# Altium schematic sheet Power.SchDoc
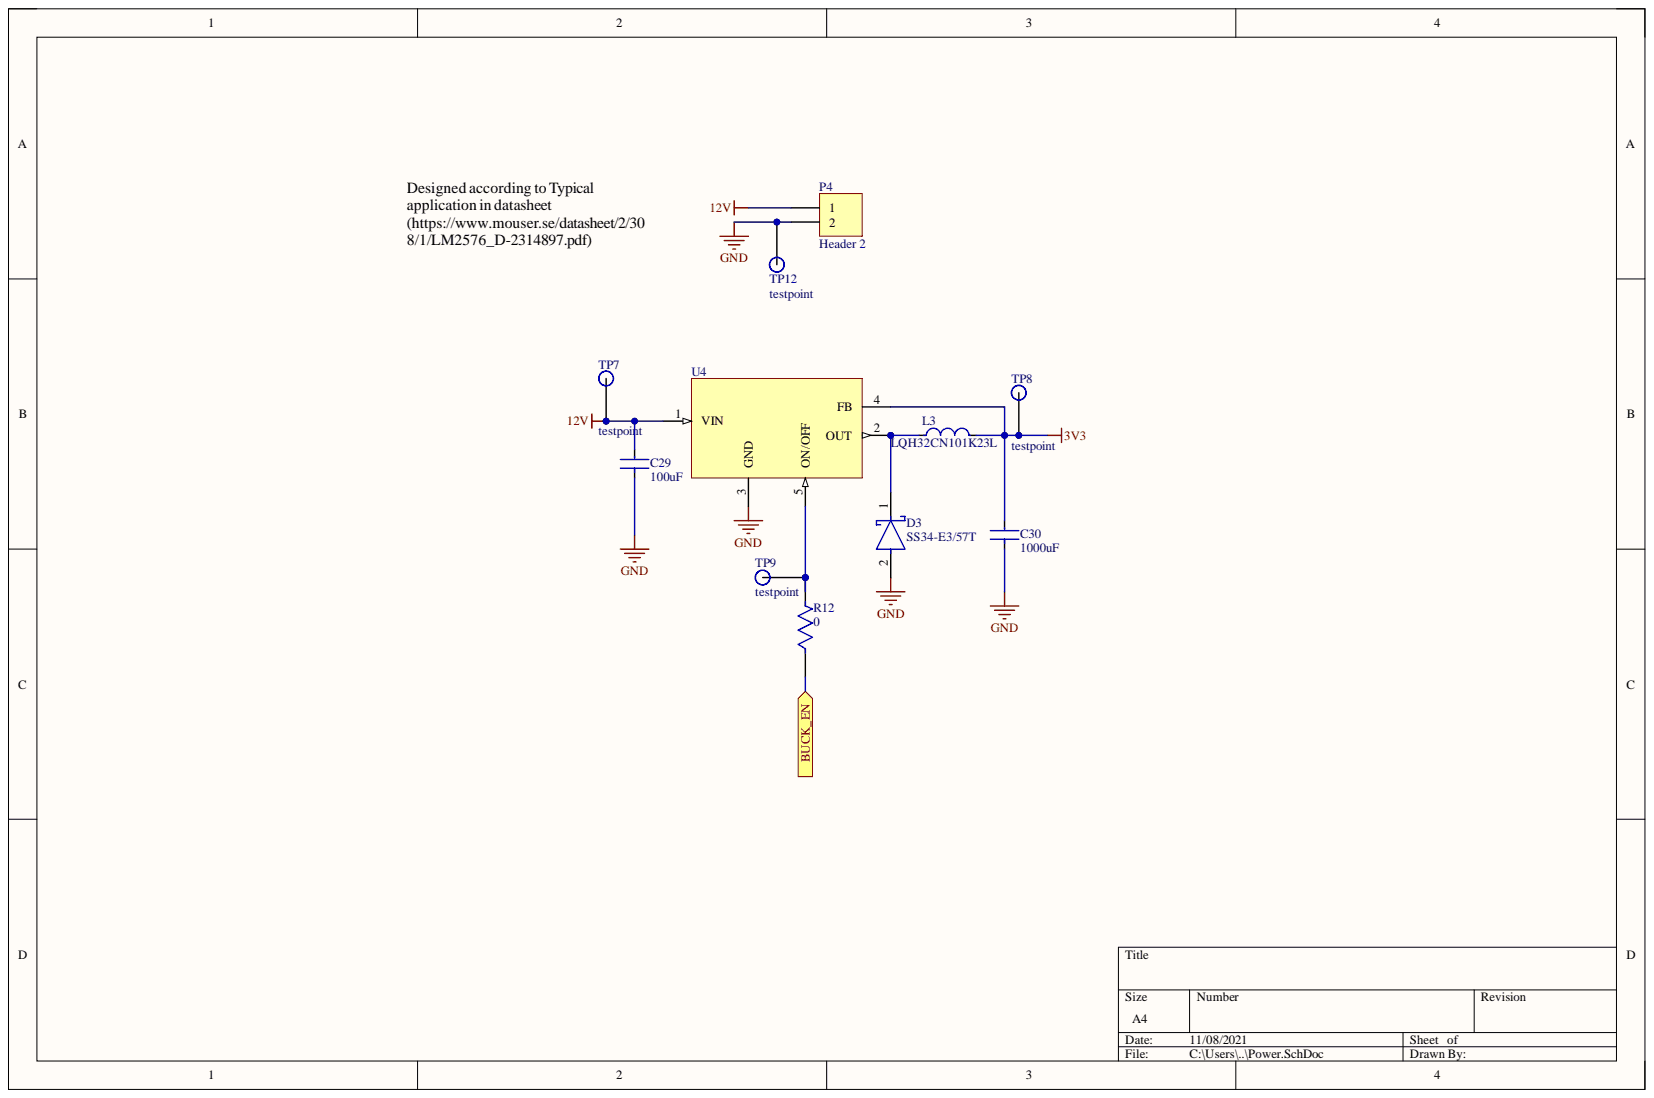
[diagram p0: Power.SchDoc]

## Parts
C29  100uF  [FP-GCJ21B-0_3-IPC_A]
C30  1000uF  [FP-GCJ21B-0_3-IPC_A]
D3  SS34-E3/57T  [FP-95402-MFG]
L3  LQH32CN101K23L  [FP-LQH32CH_23-MFG]
P4  Header 2  [HDR1X2]
R12  0  [FP-AC0603-MFG]
TP7  testpoint  [Testpoint]
TP8  testpoint  [Testpoint]
TP9  testpoint  [Testpoint]
TP12  testpoint  [Testpoint]
U4  LM2576D2TR4-3.3G  [D2PAK-5]  (value not drawn)

## Ports
BUCK_EN

## Nets
3V3: C30.2 L3.2 TP8.1 U4.4(FB)
12V: C29.2 P4.1 TP7.1 U4.1(VIN)
GND: C29.1 C30.1 D3.2 P4.2 TP12.1 U4.3(GND)
BUCK_EN: R12.1 ; port BUCK_EN
NetD3_1: D3.1 L3.1 U4.2(OUT)
NetR12_2: R12.2 TP9.1 U4.5(ON/OFF)

## Library data of the parts
C29 C30 = CMP-2007-03210-2: Multilayer Ceramic Capacitors MLCC - SMD/SMT 0805 2.2uF 10%, 16VDC,  X7R 15%; Voltage Rating: 16V; Capacitance: 2.2uF; Case Code (Imperial): 0805; Case Code (Metric): 2012; Case/Package: 0805; Component Kind: Standard; Depth: 1.25mm; Dielectric: X7R; Features: Soft Termination; Height: 1.25mm; Lead Free: Lead Free; Length: 2mm; Library Name: Altium Content Vault; Max Operating Temperature: 125°C; Min Operating Temperature: -55°C; Mount: Surface Mount; Package Quantity: 1; Packaging: Tape and Reel; Radiation Hardening: No; Ratings: AEC-Q200; Termination: SMD/SMT; Thickness: 0.057inch; Tolerance: 10%; Voltage Rating (DC): 16V; Width: 0.049inch
D3 = CMP-02539-000012-1: DIODE SCHOTTKY 40V 3A DO214AB; Manufacturer: Vishay; Max Junction Temp: +150°C; Max Surge Forward Current: 100A; Peak Reverse Current: 0.5mA; Standoff Height: 0mm; Automotive: No; Category: Diode; Component Kind: Standard; ComponentLink2Description: Datasheet; ComponentLink2URL: http://www.vishay.com/docs/88751/ss32.pdf; Device Class L1: Discrete Semiconductors; Device Class L2: Diodes; Device Class L3: Schottky Diodes; Digikey Description: DIODE SCHOTTKY 40V 3A DO214AB; Footprint Url: http://www.vishay.com/docs/95402/smc.pdf; Forward Current: 3A; Height: 2.62mm; Lead Free: Yes; Library Name: Altium Content Vault; Manufacturer Part Number: SS34-E3/57T; Max Repetitive Reverse Voltage: 40V; Mouser Description: Schottky Diodes & Rectifiers 3.0 Amp 40 Volt; Octopart: https://octopart.com/ss34-e3%2F57t-vishay-39552907; Package: 95402; RoHS: TRUE; Temperature: -55°C to +150°C; Temperature Range High: +150°C; Temperature Range Low: -55°C
L3 = CMP-06042-006648-2: Wire Wound Ferrite Inductor for Power Lines 100μH ±10% 4.55Ω 100mA 1210; Current: 1.1A; DC Resistance (DCR): 3.5R; Frequency: 10MHz; Inductance: 100uH; Q Factor: 40; Shielding: Unshielded; Test Frequency: 1MHz; Case Code (Imperial): 1210; Case Code (Metric): 3225; Case/Package: 1210; Component Kind: Standard; Core Material: Ferrite; Current Rating: 100mA; DC Current: 100mA; Depth: 2.7mm; Height: 2mm; Inductance Tolerance: 10%; Inductor Type: Wirewound; Lead Free: Lead Free; Length: 3.2mm; Library Name: Altium Content Vault; Max DC Current: 100mA; Max Operating Temperature: 85°C; Military Standard: Not; Min Operating Temperature: -40°C; Mount: Surface Mount; Number of Pins: 2; Package Quantity: 2000; Packaging: Tape and Reel; Radiation Hardening: No; Rated Current-Max: 100mA; REACH SVHC: No SVHC; RoHS Compliant: Yes; Self Resonant Frequency: 10MHz; Series: LQH32C_33; Termination: SMD/SMT; Tolerance: 10%; Weight: 0.002116oz; Width: 2.5mm
P4 = Header 2: Header, 2-Pin; Component Kind: Standard; LatestRevisionDate: 17-Jul-2002; LatestRevisionNote: Re-released for DXP Platform.; Library Name: Miscellaneous Connectors.IntLib; Signal Integrity: Connector; Publisher: Altium Limited
R12 = CMP-03412-025180-1: RES SMD 60.4 OHM 1% 1/10W 0603; Manufacturer: Yageo; Manufacturer Part Number: AC0603FR-0760R4L; Automotive Grade: Grade 0; Power Rating: 0.1W; Resistance: 60.4Ω; Tolerance: 0.01; Value: 60.4Ω; Voltage Rating: 75V; Automotive: Yes; Category: Res; Component Kind: Standard; ComponentLink2Description: Datasheet; ComponentLink2URL: http://www.yageo.com/NewPortal/yageodocoutput?fileName=/pdf/R-Chip/PYu-AC_51_RoHS_L_6.pdf; Composition: Thick Film; Device Class L1: Passive Components; Device Class L2: Resistors; Device Class L3: Chip SMD Resistors; Digikey Description: RES SMD 60.4 OHM 1% 1/10W 0603; Footprint Url: http://www.yageo.com/exep/pages/download/literatures/PYu-R_Mount_9.pdf; Height: 0.55mm; Ipc Land Pattern Name: RESC16080X45; Lead Free: Yes; Library Name: Altium Content Vault; Mouser Description: Res Thick Film 0603 60.4 Ohm 1% 0.1W(1/10W) ±100ppm/C Epoxy Pad SMD Automotive T/R; Octopart: https://octopart.com/ac0603fr-0760r4l-yageo-71367350; Package: AC0603; RoHS: TRUE; Series: AC; Temperature: -55°C to +155°C; Temperature Range High: +155°C; Temperature Range Low: -55°C
U4 = LM2576D2TR4-3.3G: http://octopart.com/lm2576d2tr4-5g-on+semiconductor-46514222; Availability: Unavailable; Component Kind: Standard; Library Name: Schlib.SchLib; MP: LM2576D2TR4-3.3G; Package: None; Price: None
TP7-TP9 TP12 = testpoint: Component Kind: Standard; Library Name: Schlib.SchLib
